# Altium schematic sheet UDZ_00_PS_Power.SchDoc
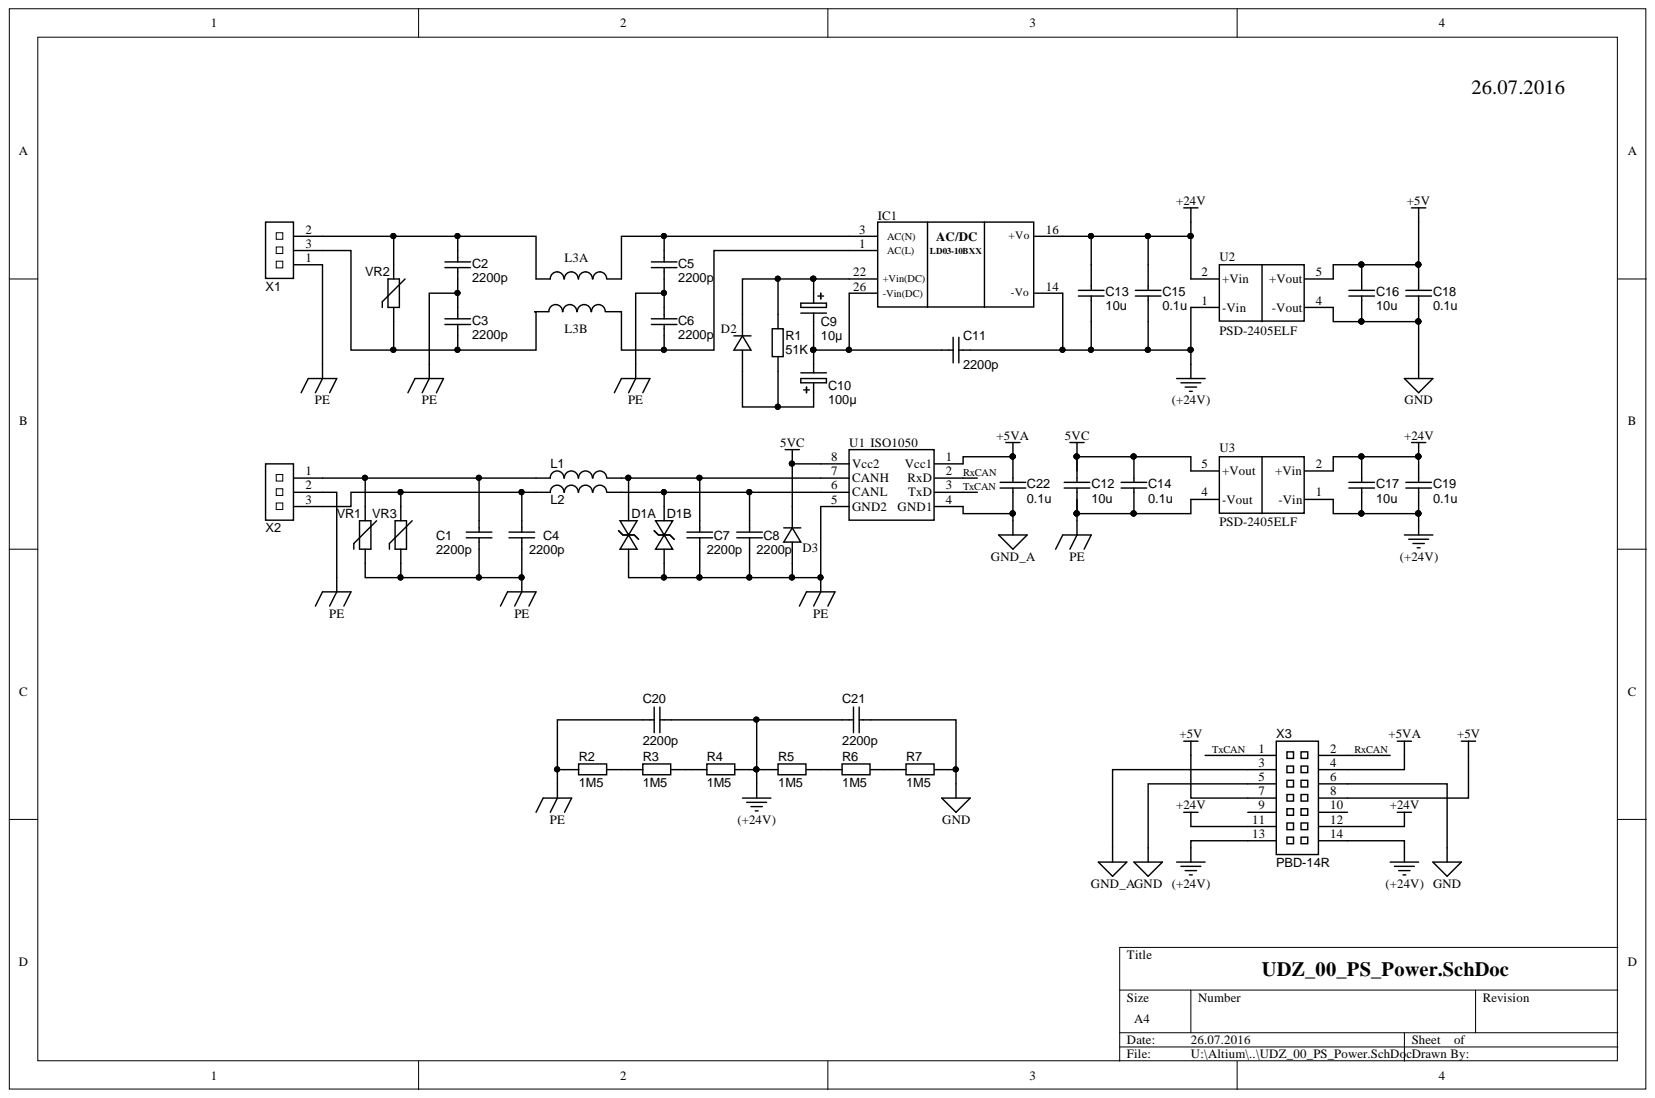
[diagram p0: UDZ_00_PS_Power.SchDoc]

## Parts
C1  value 2200p  [CAPC4532X280N]  (hidden comment: approved sub OK)
C2  value 2200p  [CAPC4532X280N]  (hidden comment: approved sub OK)
C3  value 2200p  [CAPC4532X280N]  (hidden comment: approved sub OK)
C4  value 2200p  [CAPC4532X280N]  (hidden comment: approved sub OK)
C5  value 2200p  [CAPC4532X280N]  (hidden comment: approved sub OK)
C6  value 2200p  [CAPC4532X280N]  (hidden comment: approved sub OK)
C7  value 2200p  [CAPC4532X280N]  (hidden comment: approved sub OK)
C8  value 2200p  [CAPC4532X280N]  (hidden comment: approved sub OK)
C9  10µ  [CAPE5.0MM_Rad_10x20mm]
C10  100µ  [CAPE10.0MM_Rad_25x25mm]
C11  value 2200p  [CAPC4532X280N]  (hidden comment: approved sub OK)
C12  value 10u  [CAPC3216X175N]  (hidden comment: approved sub OK)
C13  value 10u  [CAPC3216X175N]  (hidden comment: approved sub OK)
C14  value 0.1u  [CAPC3216X175N]  (hidden comment: approved sub OK)
C15  value 0.1u  [CAPC3216X175N]  (hidden comment: approved sub OK)
C16  value 10u  [CAPC3216X175N]  (hidden comment: approved sub OK)
C17  value 10u  [CAPC3216X175N]  (hidden comment: approved sub OK)
C18  value 0.1u  [CAPC3216X175N]  (hidden comment: approved sub OK)
C19  value 0.1u  [CAPC3216X175N]  (hidden comment: approved sub OK)
C20  value 2200p  [CAPC4532X280N]  (hidden comment: approved sub OK)
C21  value 2200p  [CAPC4532X280N]  (hidden comment: approved sub OK)
C22  value 0.1u  [CAPC3216X175N]  (hidden comment: approved sub OK)
D1  NUP2105L  [SOT23-3]  (value not drawn)  (parts A,B)
D2  MURS140T3  [DO-214AA]  (value not drawn)
D3  DIODE_10BQ100  [DO-214AA]  (value not drawn)
IC1  AC/DC LD03-series  [Mornsun LD03-SERIES]  (value not drawn)
L1  Value  [INDUCTOR_PCB]  (value not drawn)  (no BOM)
L2  Value  [INDUCTOR_PCB]  (value not drawn)  (no BOM)
L3  SMP-C3323  [Filter SMP]  (value not drawn)  (parts A,B)
R1  value 51K  [RESC3216N_ZERO]  (hidden comment: Thick Film)
R2  value 1М5  [RESC6332X70N]  (hidden comment: Thick Film)
R3  value 1М5  [RESC6332X70N]  (hidden comment: Thick Film)
R4  value 1М5  [RESC6332X70N]  (hidden comment: Thick Film)
R5  value 1М5  [RESC6332X70N]  (hidden comment: Thick Film)
R6  value 1М5  [RESC6332X70N]  (hidden comment: Thick Film)
R7  value 1М5  [RESC6332X70N]  (hidden comment: Thick Film)
U1  ISO1050  [Dub3]
U2  PSD-2405ELF  [PSD-0505ELF]
U3  PSD-2405ELF  [PSD-0505ELF]
VR1  Varistor  [JVR05]  (value not drawn)
VR2  Varistor  [JVR05]  (value not drawn)
VR3  Varistor  [JVR05]  (value not drawn)
X1  WAGO 231-433  [1x3_WAGO_231-433]  (value not drawn)
X2  WAGO 231-533  [1x3_WAGO_231-533]  (value not drawn)
X3  PBD-14R  [14P_PCB_PBD-14R]

## Nets
5VC: C12.1 C14.1 D3.K U1.8(Vcc2) U3.5(+Vout)
(+24V): C11.2 C13.2 C15.2 C17.2 C19.2 C20.2 C21.1 IC1.14(-Vo) R4.2 R5.1 U2.1(-Vin) U3.1(-Vin) X3.13 X3.14
+5V: C16.1 C18.1 U2.5(+Vout) X3.7 X3.8
+5VA: C22.1 U1.1(Vcc1) X3.4
+24V: C13.1 C15.1 C17.1 C19.1 IC1.16(+Vo) U2.2(+Vin) U3.2(+Vin) X3.11 X3.12
GND: C16.2 C18.2 C21.2 R7.2 U2.4(-Vout) X3.5 X3.6
GND_A: C22.2 U1.4(GND1) X3.3
PE: C1.2 C2.2 C3.1 C4.2 C5.2 C6.1 C7.2 C8.2 C12.2 C14.2 C20.1 D1.3 D3.A R2.1 U1.5(GND2) U3.4(-Vout) VR1.2 VR3.2 X1.1 X2.2
RXCAN: U1.2(RxD) X3.2
TXCAN: U1.3(TxD) X3.1
NetC1_1: C1.1 L1.1 VR1.1 X2.1
NetC2_1: C2.1 L3.2 VR2.1 X1.2
NetC3_2: C3.2 L3.3 VR2.2 X1.3
NetC4_1: C4.1 L2.1 VR3.1 X2.3
NetC5_1: C5.1 IC1.3(AC(N)) L3.1
NetC6_2: C6.2 IC1.1(AC(L)) L3.4
NetC7_1: C7.1 D1.1 L1.2 U1.7(CANH)
NetC8_1: C8.1 D1.2 L2.2 U1.6(CANL)
NetC9_1: C9.1 D2.K IC1.22(+Vin(DC)) R1.1
NetC9_2: C9.2 C10.2 C11.1 IC1.26(-Vin(DC))
NetC10_1: C10.1 D2.A R1.2
NetR2_2: R2.2 R3.1
NetR3_2: R3.2 R4.1
NetR5_2: R5.2 R6.1
NetR6_2: R6.2 R7.1

Not connected: X3 9 10

## Library data of the parts
C1-C8 C11 C20 C21 = CAPC_SMD_1812_3kV: CAPC SMD1812 3kV; Name: Конденсатор AVX 1812HC222KAT1A (SMD1812; 3kV); Component Kind: Standard; Library Name: PBL - Capacitors.SCHLIB
C9 = CAPE_10u_400V_THD: Capacitor THD Electrolytic 10uF 20% 400V Radial; Name: ECAP 10/400V 10х20 (H<20); Component Kind: Standard; Value: 10µ; Library Name: Libs_Rutledge.IntLib
C10 = CAPE_100u_400V_THD: Capacitor THD Electrolytic 100uF 20% 400V Radial; Name: ECAP 100/400V 25x25 (YAG); Component Kind: Standard; Library Name: PBL - Capacitors.SCHLIB; Value: 100µ
C12-C19 C22 = CAP_SMD_1206: Capacitor SMD 50V X7R 1206; Name: Чип-конденсатор 50V X7R 1206; Component Kind: Standard; Library Name: Libs_Rutledge.IntLib
D1 = DIODE_DOUBLE_ZENER: DIODE_NUP2105L; Name: Стабилитрон NUP2105L (SOT 23-3); Component Kind: Standard; Library Name: Libs_Rutledge.IntLib
D2 = DIODE_MURS140T3: Диод выпрямительный 400V 1A; Name: Диод MURS140T3 (DO-214AA); Component Kind: Standard; Library Name: PBL - Diodes.SCHLIB
D3 = DIODE_10BQ100: Диод Шоттки 100V 1A; Name: Диод Шоттки 10BQ100 (DO-214AA); Component Kind: Standard; Library Name: PBL - Diodes.SCHLIB
IC1 = AC/DC LD03-10BXX: Mornsun LD03-10B24; Name: AC/DC преобразователь Mornsun LD03-10B24; Component Kind: Standard; Library Name: PBL - Power Supplies.SCHLIB
L1 L2 = Inductor on PCB: Description: Inductor on PCB; Library Name: PBL - Inductors.SCHLIB
L3 = Inductor SMP-C3323: Фильтр SMP-C3323; Component Kind: Standard; Name: Фильтр SMP-C3323; Library Name: Libs_Rutledge.IntLib
R1 = RES_0R_1206: Resistor SMD chip 0.0 Ohm 0.5W 1206; Name: Чип-резистор 1206 5%; Component Kind: Standard; Library Name: Libs_Rutledge.IntLib
R2-R7 = RES_3K3_2512_5%: Resistor SMD chip 3.3k Ohm 1W 5% 2512; Name: Чип резистор 2512-1Вт-5%; Component Kind: Standard; Library Name: Libs_Rutledge.IntLib
U1 = ISO1050: ISOLATED_CAN_TRANSCEIVER_ISO1050; Name: Mикросхема ISO1050 (DUB); Component Kind: Standard; Library Name: PBL - Integrated Circuits.SCHLIB
U2 = PSD-0505ELF: Гальваническая развязка 5/5; Analog: PSD1-A-2405E (PEAK); Name: DC/DC converter PSD-2405ELF (SMD); Component Kind: Standard; Library Name: PBL - Integrated Circuits.SCHLIB
U3 = PSD-0505ELF: Гальваническая развязка 5/5; Name: DC/DC converter PSD-2405ELF (SMD); Component Kind: Standard; Library Name: PBL - Integrated Circuits.SCHLIB
VR1-VR3 = Varistor_JVR05: Name: Варистор JVR05N431; Value: 430V; Component Kind: Standard; Description: Varistor_JVR05; Library Name: Libs_Rutledge.IntLib
X1 = WAGO 231-433: Разъем угловой WAGO 231-433, вилка на плату; Name: Комплект разъемов плата - кабель WAGO (231-103 и 231-433); Component Kind: Standard; Library Name: PBL - Connectors.SCHLIB
X2 = WAGO 231-533: Разъем угловой WAGO 231-533, угловой; Name: Комплект разъемов плата - кабель WAGO (2232-303 и 231-533); Component Kind: Standard; Library Name: PBL - Connectors.SCHLIB
X3 = PBD-14R: Разъем PBD-14 двойной угл. 2,54 мм.; Name: Разъем PBD-14R двойной угл. 2,54 мм.; Value: Double-row, 10 positions; Component Kind: Standard; Library Name: PBL - Connectors.SCHLIB
